# Altium schematic sheet Filter.SchDoc
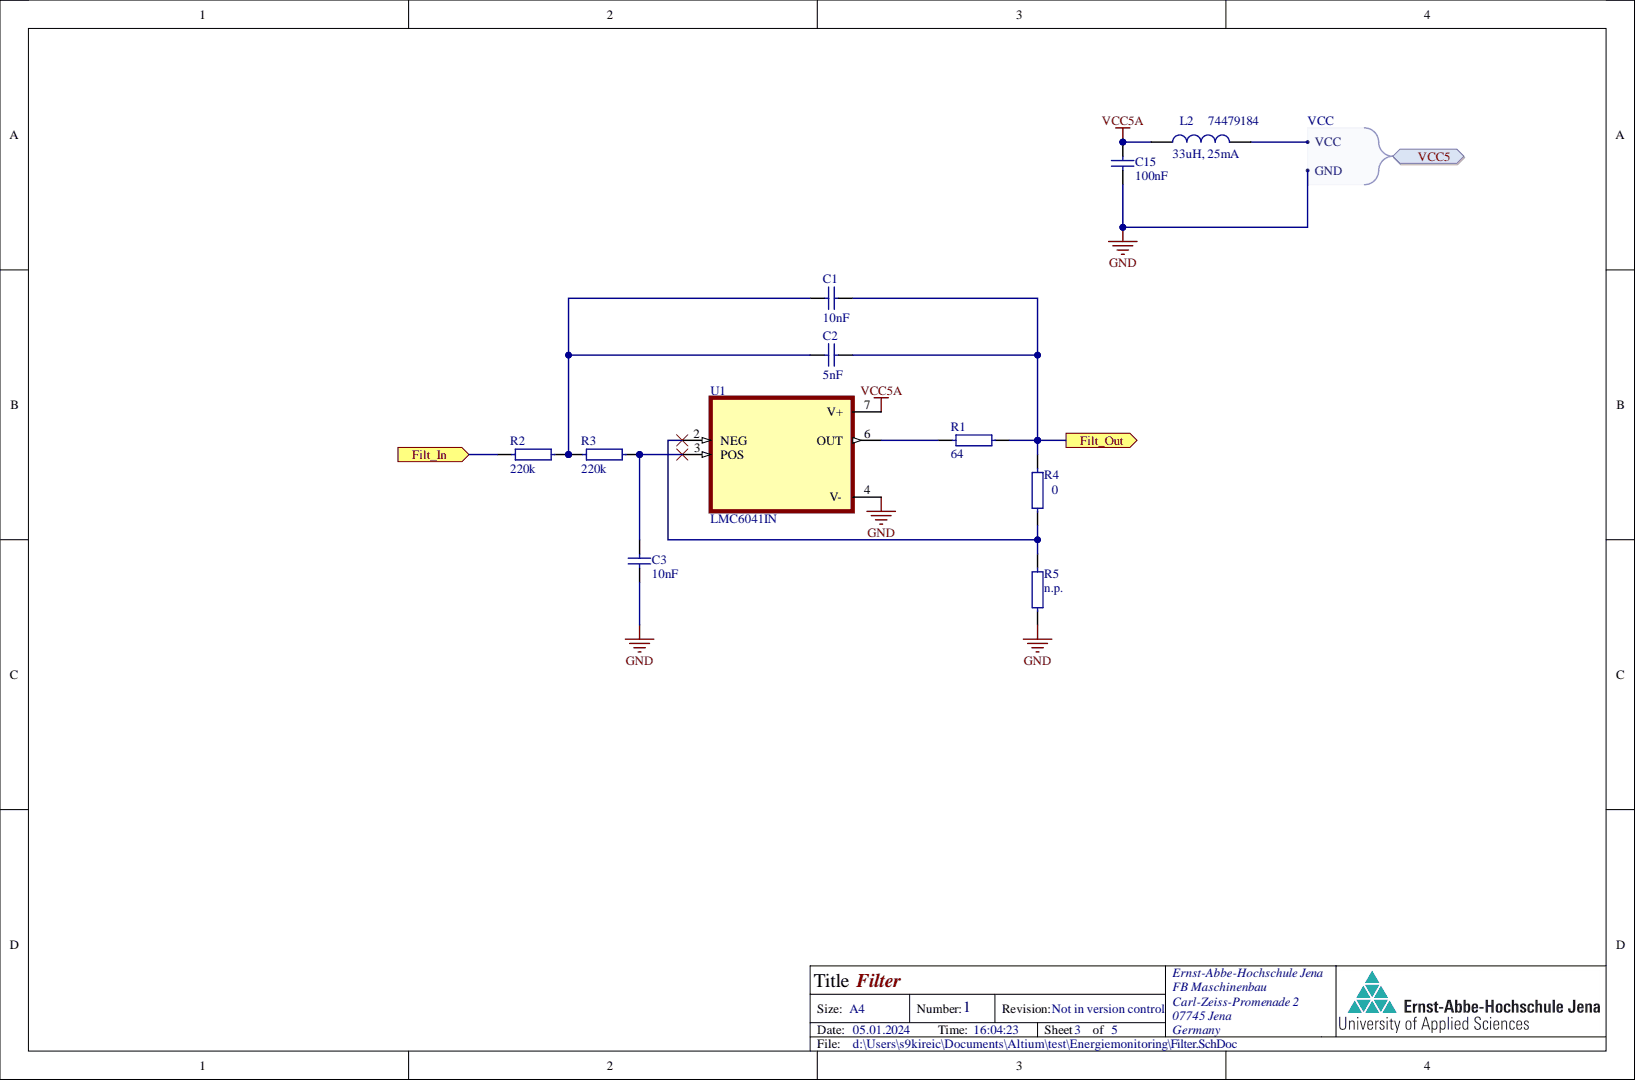
[diagram p0: Filter.SchDoc]

## Parts
C1  10nF  [SMD-0805]
C2  5nF  [SMD-0805]
C3  10nF  [SMD-0805]
C15  100nF  [SMD-0805]
L2  33uH, 25mA  Rated Current: 25mA  [WE-MI_1206]
R1  64  [R-SMD-0805]
R2  220k  [R-SMD-0805]
R3  220k  [R-SMD-0805]
R4  0  [R-SMD-0805]
R5  n.p.  [R-SMD-0805]
U1  LMC6041IN  [DIP794W45P254L959H508Q8]

## Ports
Filt_In, Filt_Out, VCC5, VCC5.GND, VCC5.VCC

## Nets
VCC5A: C15.2 L2.1 U1.7(V+)
Filt_In: R2.1 ; port Filt_In
Filt_Out: C1.2 C2.2 R1.2 R4.1 ; port Filt_Out
GND: C3.1 C15.1 R5.2 U1.4(V-) ; port VCC5
VCC5: L2.2 ; port VCC5
NetC1_1: C1.1 C2.1 R2.2 R3.1
NetC3_2: C3.2 R3.2 U1.3(POS)
NetR1_1: R1.1 U1.6(OUT)
NetR4_2: R4.2 R5.1 U1.2(NEG)

## Library data of the parts
C1-C3 C15 = C: Kondensator; Component Kind: Standard; Library Name: SMD.IntLib
  C1 C3: Value: 10nF
  C2: Value: 5nF
  C15: Value: 100nF
L2 = 74479184: WE-MI SMT Multilayer Inductor, size 1206, 33uH, 25mA; Manufacturer: Wurth Elektronik; DC Resistance max.: 0.9Ohm; DC Resistance typ.: 0.65Ohm; Q-Factor: 35; Component Kind: Standard; ComponentLink1Description: Manufacturer; ComponentLink1URL: http://www.we-online.de; ComponentLink2Description: Datasheet; ComponentLink2URL: https://www.we-online.com/catalog/datasheet/74479184.pdf; Inductance: 33uH; Manufacturer Part Number: 74479184; Mounting Technology: SMT; Operating Temperature: -55 to +125degC; Packaging: Tape and Reel; Published Date: April 2020; Size: 1206; Library Name: Altium_WE_eiSos_WE-MI.IntLib
R1-R5 = R: Widerstand; Component Kind: Standard; Library Name: SMD.IntLib
  R1: Value: 64
  R2 R3: Value: 220k
  R4: Value: 0
  R5: Value: n.p.
U1 = LMC6041IN: CMOS Single Micropower Operational Amplifier 8-PDIP -40 to 85; MF: Texas Instruments; MP: LMC6041IN/NOPB; Availability: In Stock; Check_prices: https://www.snapeda.com/parts/LMC6041IN/NOPB/Texas+Instruments/view-part/?ref=eda; Component Kind: Standard; Library Name: LMC6041IN.IntLib; Package: PDIP-8 Texas; Price: None; Purchase-URL: https://pricing.snapeda.com/search/part/LMC6041IN/NOPB/?ref=eda; SnapEDA_Link: https://www.snapeda.com/parts/LMC6041IN/NOPB/Texas+Instruments/view-part/?ref=snap
